# Altium schematic sheet Analog Out Amplifiers PWM Rev 6.SchDoc("Analog Out Amplifiers PWM Rev 6")
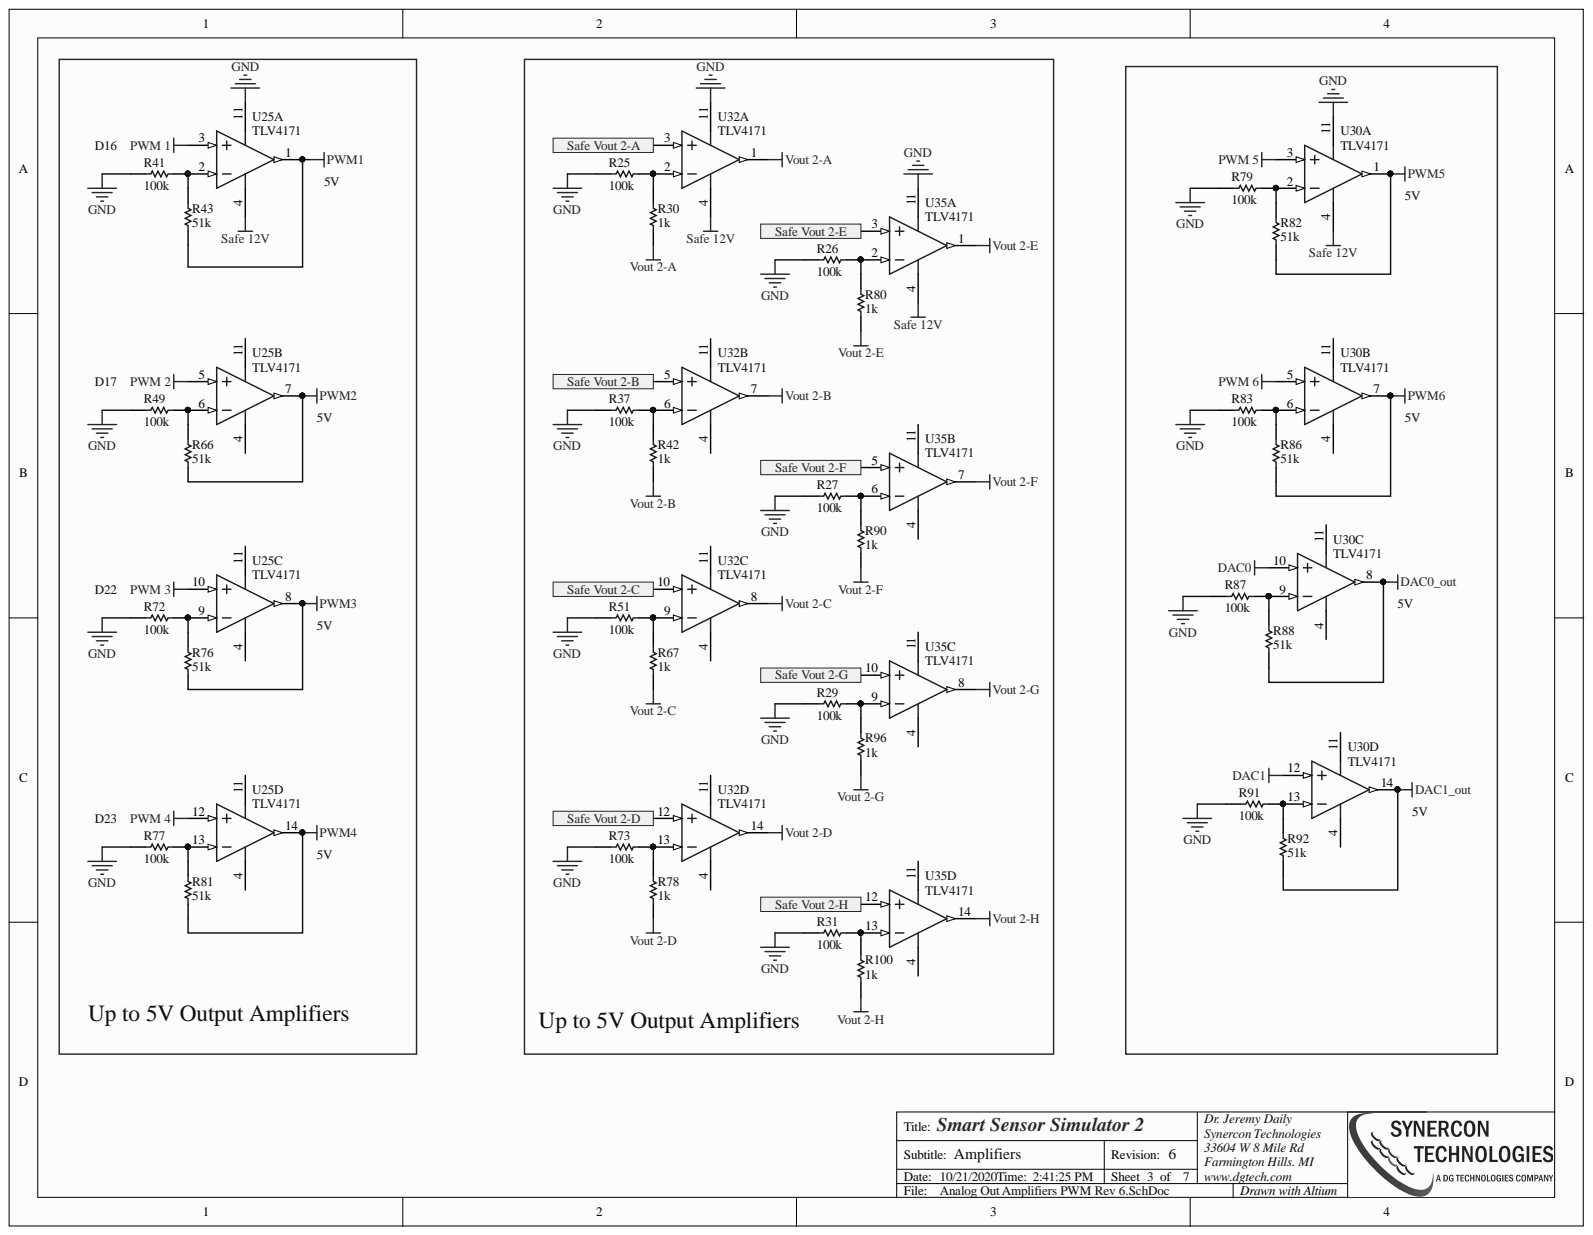
[diagram p0: Analog Out Amplifiers PWM Rev 6.SchDoc]

## Parts
R25  100k  [0603]
R26  100k  [0603]
R27  100k  [0603]
R29  100k  [0603]
R30  1k  [0603]
R31  100k  [0603]
R37  100k  [0603]
R41  100k  [0603]
R42  1k  [0603]
R43  51k  [0603]
R49  100k  [0603]
R51  100k  [0603]
R66  51k  [0603]
R67  1k  [0603]
R72  100k  [0603]
R73  100k  [0603]
R76  51k  [0603]
R77  100k  [0603]
R78  1k  [0603]
R79  100k  [0603]
R80  1k  [0603]
R81  51k  [0603]
R82  51k  [0603]
R83  100k  [0603]
R86  51k  [0603]
R87  100k  [0603]
R88  51k  [0603]
R90  1k  [0603]
R91  100k  [0603]
R92  51k  [0603]
R96  1k  [0603]
R100  1k  [0603]
U25  TLV4171  [TI-PW14_M]  (parts A,B,C,D)
U30  TLV4171  [TI-PW14_M]  (parts A,B,C,D)
U32  TLV4171  [TI-PW14_M]  (parts A,B,C,D)
U35  TLV4171  [TI-PW14_M]  (parts A,B,C,D)

## Ports
Safe Vout 2-A, Safe Vout 2-B, Safe Vout 2-C, Safe Vout 2-D, Safe Vout 2-E, Safe Vout 2-F, Safe Vout 2-G, Safe Vout 2-H

## Nets
DAC0: U30.10
DAC0_out: R88.2 U30.8
DAC1: U30.12
DAC1_out: R92.2 U30.14
GND: R25.2 R26.2 R27.2 R29.2 R31.2 R37.2 R41.2 R49.2 R51.2 R72.2 R73.2 R77.2 R79.2 R83.2 R87.2 R91.2 U25.11 U30.11 U32.11 U35.11
PWM 1: R43.2 U25.1 U25.3
PWM 2: R66.2 U25.5 U25.7
PWM 3: R76.2 U25.8 U25.10
PWM 4: R81.2 U25.12 U25.14
PWM 5: R82.2 U30.1 U30.3
PWM 6: R86.2 U30.5 U30.7
Safe 12V: U25.4 U30.4 U32.4 U35.4
Vout 2-A: R30.2 U32.1
Vout 2-B: R42.2 U32.7
Vout 2-C: R67.2 U32.8
Vout 2-D: R78.2 U32.14
Vout 2-E: R80.2 U35.1
Vout 2-F: R90.2 U35.7
Vout 2-G: R96.2 U35.8
Vout 2-H: R100.2 U35.14
Safe Vout 2-A: U32.3 ; port Safe Vout 2-A
Safe Vout 2-B: U32.5 ; port Safe Vout 2-B
Safe Vout 2-C: U32.10 ; port Safe Vout 2-C
Safe Vout 2-D: U32.12 ; port Safe Vout 2-D
Safe Vout 2-E: U35.3 ; port Safe Vout 2-E
Safe Vout 2-F: U35.5 ; port Safe Vout 2-F
Safe Vout 2-G: U35.10 ; port Safe Vout 2-G
Safe Vout 2-H: U35.12 ; port Safe Vout 2-H
NetR25_1: R25.1 R30.1 U32.2
NetR26_1: R26.1 R80.1 U35.2
NetR27_1: R27.1 R90.1 U35.6
NetR29_1: R29.1 R96.1 U35.9
NetR31_1: R31.1 R100.1 U35.13
NetR37_1: R37.1 R42.1 U32.6
NetR41_1: R41.1 R43.1 U25.2
NetR49_1: R49.1 R66.1 U25.6
NetR51_1: R51.1 R67.1 U32.9
NetR72_1: R72.1 R76.1 U25.9
NetR73_1: R73.1 R78.1 U32.13
NetR77_1: R77.1 R81.1 U25.13
NetR79_1: R79.1 R82.1 U30.2
NetR83_1: R83.1 R86.1 U30.6
NetR87_1: R87.1 R88.1 U30.9
NetR91_1: R91.1 R92.1 U30.13

## Library data of the parts
R25-R27 R29 R31 R37 R41 R49 R51 R72 R73 R77 R79 R83 R87 R91 = Chip Resistor: 100 kOhms ±1% 0.1W, 1/10W Chip Resistor 0603 (1608 Metric) Automotive AEC-Q200 Thick Film; PartNumber: ERJ-3EKF1003V; Manufacturer: Panasonic Electronic Components; Alternative: Acceptable; Supplier Part Number 1: P100KHCT-ND; Supplier 1: Digi-Key; Library Name: SSS Integrated Library.IntLib; Component Kind: Standard
R30 R42 R67 R78 R80 R90 R96 R100 = Chip Resistor: RES SMD 1K OHM 1% 1/10W 0603; PartNumber: ERJ-3EKF1001V; Manufacturer: Panasonic Electronic Components; Alternative: Acceptable; Supplier Part Number 1: P1.00KHCT-ND; Supplier 1: Digi-Key; Library Name: SSS Schematic Library.SchLib; Component Kind: Standard
R43 R66 R76 R81 R82 R86 R88 R92 = Chip Resistor: RES SMD 51K OHM 1% 1/10W 0603; PartNumber: ERJ-3EKF5102V; Manufacturer: Panasonic Electronic Components; Supplier Part Number 1: P51.0KHCT-ND; Supplier 1: Digi-Key; Library Name: SSS Integrated Library.IntLib; Component Kind: Standard
U25 U30 U32 U35 = TL064CPW: Quad Low-Power JFET-Input General-Purpose Operational Amplifier, 7 to 36 V, 0 to 70 degC, 14-pin SOP (PW14), Green (RoHS & no Sb/Br); PartNumber: TLV4171IPWR; Manufacturer: Texas Instruments; Supplier Part Number 1: 296-44866-1-ND; Supplier 1: Digi-Key; Library Name: TI Amplifiers and Linear Operational Amplifier General Purpose.IntLib; Component Kind: Standard
